# Altium schematic sheet LED CONTROLLER.SchDoc("LED CONTROLLER")
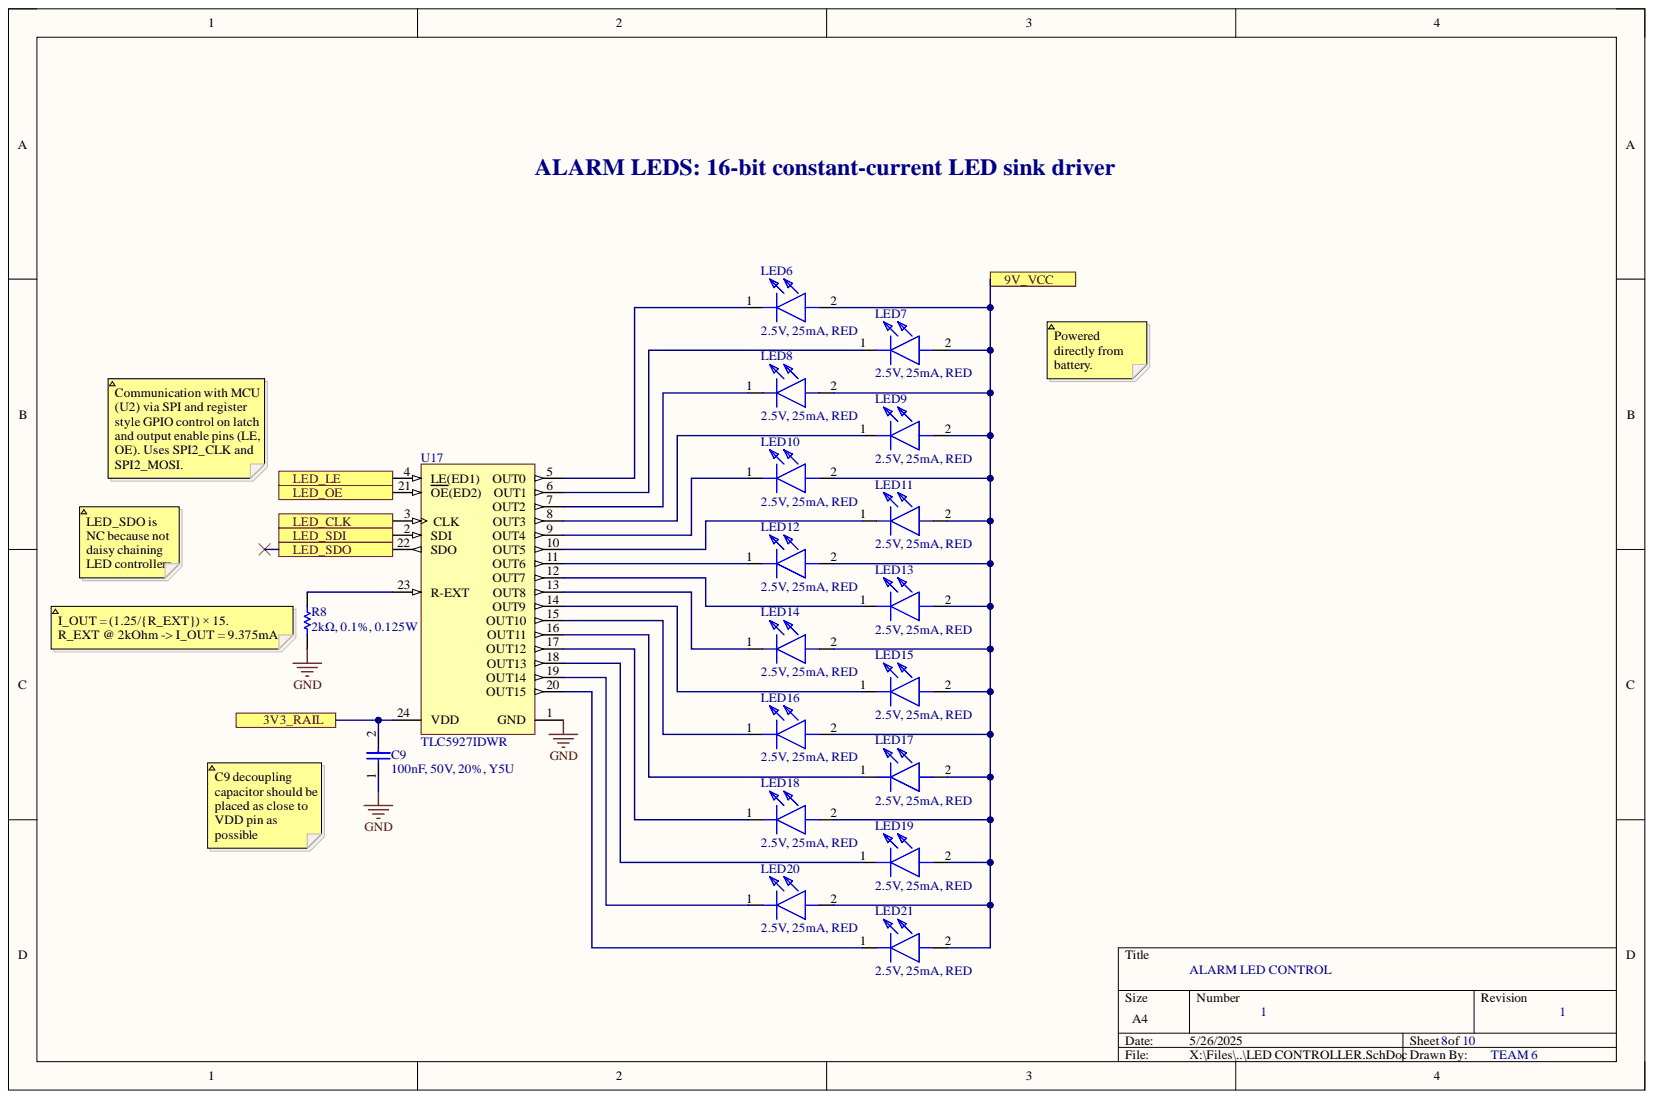
[diagram p0: LED CONTROLLER.SchDoc]

## Parts
C9  100nF, 50V, 20%, Y5U  [Capacitor]
LED6  2.5V, 25mA, RED  [LEDC2012X80N]
LED7  2.5V, 25mA, RED  [LEDC2012X80N]
LED8  2.5V, 25mA, RED  [LEDC2012X80N]
LED9  2.5V, 25mA, RED  [LEDC2012X80N]
LED10  2.5V, 25mA, RED  [LEDC2012X80N]
LED11  2.5V, 25mA, RED  [LEDC2012X80N]
LED12  2.5V, 25mA, RED  [LEDC2012X80N]
LED13  2.5V, 25mA, RED  [LEDC2012X80N]
LED14  2.5V, 25mA, RED  [LEDC2012X80N]
LED15  2.5V, 25mA, RED  [LEDC2012X80N]
LED16  2.5V, 25mA, RED  [LEDC2012X80N]
LED17  2.5V, 25mA, RED  [LEDC2012X80N]
LED18  2.5V, 25mA, RED  [LEDC2012X80N]
LED19  2.5V, 25mA, RED  [LEDC2012X80N]
LED20  2.5V, 25mA, RED  [LEDC2012X80N]
LED21  2.5V, 25mA, RED  [LEDC2012X80N]
R8  2kΩ, 0.1%, 0.125W  [RESC2013X60X40ML20T25]
U17  TLC5927IDWR  [DW0024A_L]

## Ports
3V3_RAIL, 9V_VCC, LED_CLK, LED_LE, LED_OE, LED_SDI, LED_SDO

## Nets
GND: C9.1 R8.1 U17.1(GND)
3V3_RAIL: C9.2 U17.24(VDD) ; port 3V3_RAIL
9V_VCC: LED6.2 LED7.2 LED8.2 LED9.2 LED10.2 LED11.2 LED12.2 LED13.2 LED14.2 LED15.2 LED16.2 LED17.2 LED18.2 LED19.2 LED20.2 LED21.2 ; port 9V_VCC
LED_CLK: U17.3(CLK) ; port LED_CLK
LED_LE: U17.4(LE(ED1)) ; port LED_LE
LED_OE: U17.21(OE(ED2)) ; port LED_OE
LED_SDI: U17.2(SDI) ; port LED_SDI
LED_SDO: U17.22(SDO) ; port LED_SDO
NetLED6_1: LED6.1 U17.5(OUT0)
NetLED7_1: LED7.1 U17.6(OUT1)
NetLED8_1: LED8.1 U17.7(OUT2)
NetLED9_1: LED9.1 U17.8(OUT3)
NetLED10_1: LED10.1 U17.9(OUT4)
NetLED11_1: LED11.1 U17.10(OUT5)
NetLED12_1: LED12.1 U17.11(OUT6)
NetLED13_1: LED13.1 U17.12(OUT7)
NetLED14_1: LED14.1 U17.13(OUT8)
NetLED15_1: LED15.1 U17.14(OUT9)
NetLED16_1: LED16.1 U17.15(OUT10)
NetLED17_1: LED17.1 U17.16(OUT11)
NetLED18_1: LED18.1 U17.17(OUT12)
NetLED19_1: LED19.1 U17.18(OUT13)
NetLED20_1: LED20.1 U17.19(OUT14)
NetLED21_1: LED21.1 U17.20(OUT15)
NetR8_2: R8.2 U17.23(R-EXT)

## Library data of the parts
C9 = 5ZH104MACJI: Ceramic Disc Capacitors 50volt 100nF 20% Y5V/III; Manufacturer: Kyocera AVX; Application(s): GENERAL PURPOSE; Dielectric: Y5V; Lead/Base Style: Straight; Capacitance: 100nF; Case/Package: Radial; Category: Ceramic Capacitors; Component Kind: Standard; ComponentLink1Description: https://datasheet.ciiva.com/237/class3-sc-237088.pdf?src-supplier=Digikey; ComponentLink1URL: https://datasheet.ciiva.com/237/class3-sc-237088.pdf?src-supplier=Digikey; ComponentLink2Description: https://datasheet.ciiva.com/237/class3-sc-237089.pdf?src-supplier=Digikey; ComponentLink2URL: https://datasheet.ciiva.com/237/class3-sc-237089.pdf?src-supplier=Digikey; Diameter: 6mm; Height - Seated (Max): 0.118inch; Lead Free: Lead Free; Lead Pitch: 0.197inch; Library Name: Copy of Schlib2.SchLib; Manufacturer Part Number: 5ZH104MACJI; Max Operating Temperature: 85°C; Min Operating Temperature: -30°C; Mount: Through Hole; Package Quantity: 10; Packaging: Cut Tape; RoHS: No; RoHS Compliant: No; Supplier 1: Digikey; Supplier Part Number 1: 478-4275-3-ND; Termination: Radial; Tolerance: 20%; Voltage Rating: 50V; Voltage Rating (DC): 50V
LED6 = SM0805HC: LS R976-NR-1 2.5V RED; Manufacturer_Name: Bivar; Arrow Part Number: SM0805HC; Arrow Price/Stock: https://www.arrow.com/en/products/sm0805hc/bivar?region=nac; Component Kind: Standard; Datasheet Link: https://www.arrow.com/en/products/sm0805hc/bivar?region=nac; Height: 0.8mm; Manufacturer_Part_Number: SM0805HC; Mouser Part Number: 749-SM0805HC; Mouser Price/Stock: https://www.mouser.co.uk/ProductDetail/Bivar/SM0805HC?qs=jaLxTFIJCitzwkI3Y6uptQ%3D%3D
LED7-LED21 = SM0805HC: LED; Manufacturer_Name: Bivar; Arrow Part Number: SM0805HC; Arrow Price/Stock: https://www.arrow.com/en/products/sm0805hc/bivar?region=nac; Component Kind: Standard; Datasheet Link: https://www.arrow.com/en/products/sm0805hc/bivar?region=nac; Height: 0.8mm; Manufacturer_Part_Number: SM0805HC; Mouser Part Number: 749-SM0805HC; Mouser Price/Stock: https://www.mouser.co.uk/ProductDetail/Bivar/SM0805HC?qs=jaLxTFIJCitzwkI3Y6uptQ%3D%3D
R8 = CMP-2001-00609-1: ERA-6AEB202V RES SMD 2K OHM 0.1% 1/8W 0805; Manufacturer: Panasonic; Part Number: ERA6AEB202V; Component Kind: Standard; Library Name: Altium Content Vault
U17 = CMP-0388-00271-2: 16-Bit Constant-Current LED Sink Driver, 3 to 5.5 V, -40 to 85 degC, 24-pin SOIC (DW24), Green (RoHS & no Sb/Br); Manufacturer: Texas Instruments; Rating: Catalog; SubFamily: LED Driver; Vin(Max)(V): 5.5; Vin(Min)(V): 3; Code_JEDEC: MS-013; Component Kind: Standard; ComponentLink1Description: Manufacturer URL; ComponentLink1URL: http://www.ti.com/; ComponentLink2Description: Datasheet; ComponentLink2URL: http://www.ti.com/general/docs/lit/getliterature.tsp?genericPartNumber=TLC5927&fileType=pdf; ComponentLink3Description: Package Specification; ComponentLink3URL: http://www.ti.com/litv/pdf/mpds174a; DatasheetVersion: SLVS677; Eco-Plan: Green (RoHS & no Sb/Br); Library Name: Altium Content Vault; Mounting Technology: Surface Mount; PackageDescription: 24-Pin Plastic Small Outline, Body 15.4 x 7.5 mm, Pitch 1.27 mm; PackageReference: DW0024A; PackageVersion: 4040000-5/G; PartNumber: TLC5927IDWR
